# Altium schematic sheet Support.SchDoc
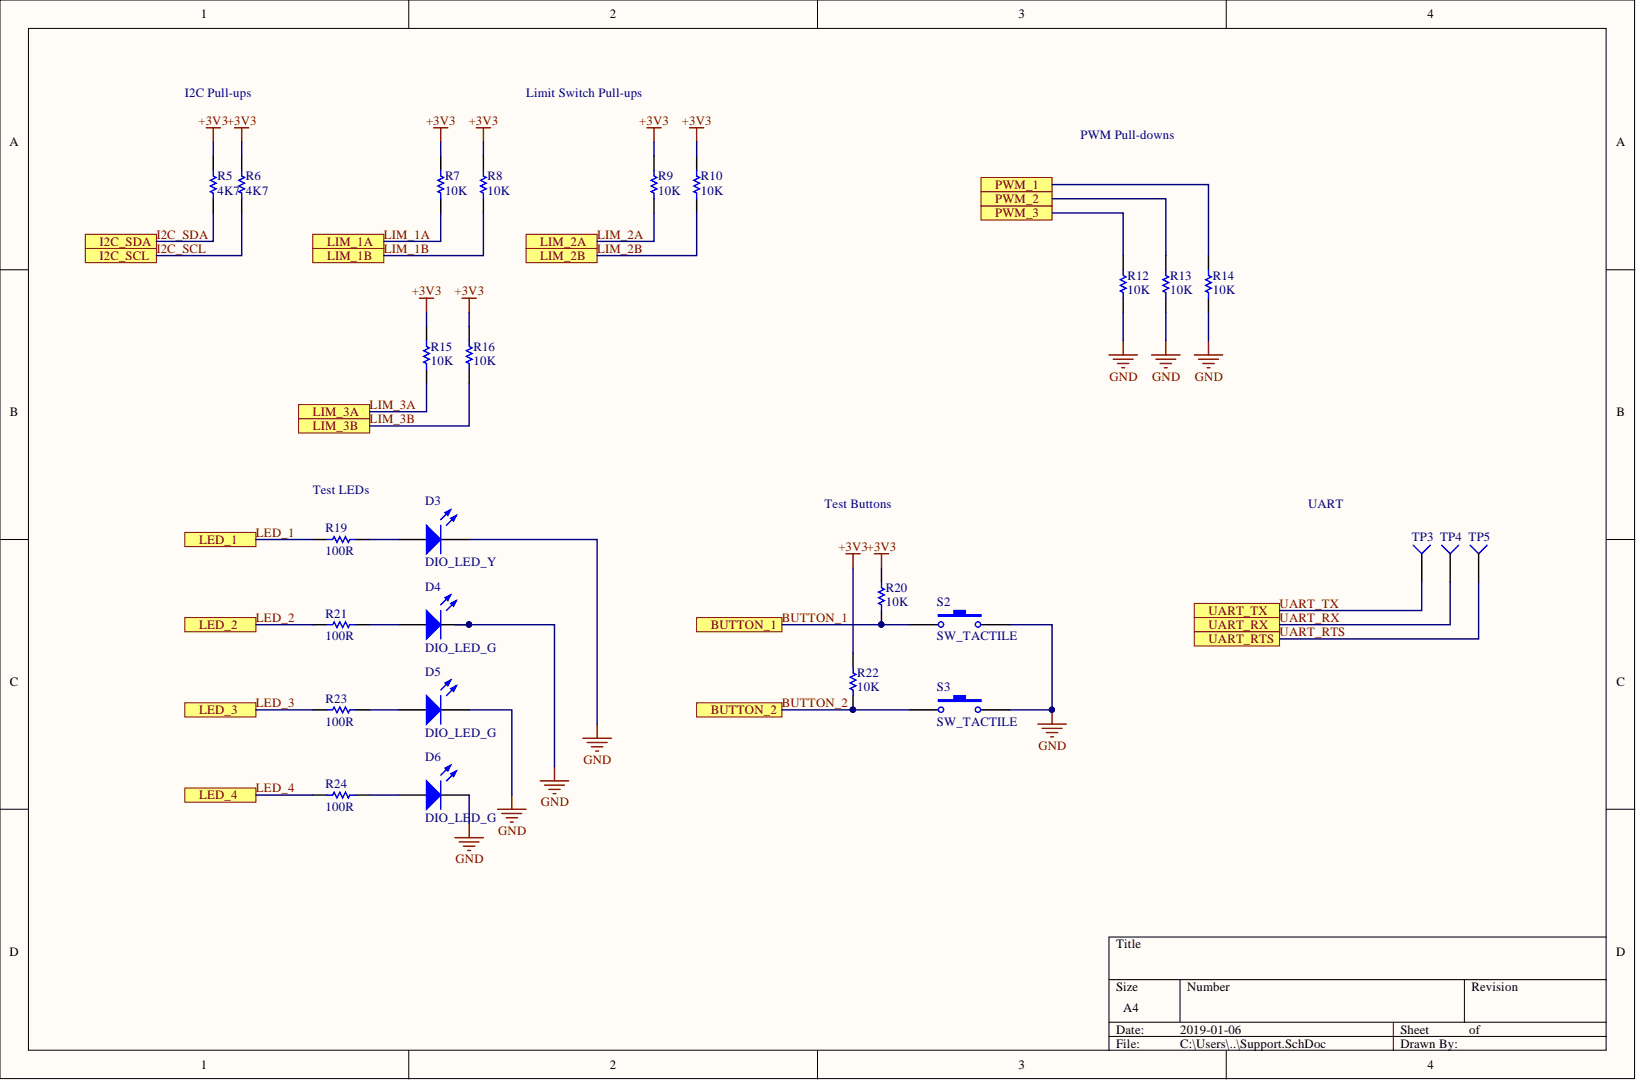
[diagram p0: Support.SchDoc]

## Parts
D3  DIO_LED_Y  [LED_0805]
D4  DIO_LED_G  [LED_0805]
D5  DIO_LED_G  [LED_0805]
D6  DIO_LED_G  [LED_0805]
R5  value 4K7  [RESC0603(1608)_N]  (hidden comment: RES_4K7_0603)
R6  value 4K7  [RESC0603(1608)_N]  (hidden comment: RES_4K7_0603)
R7  value 10K  [RESC0603(1608)_N]  (hidden comment: RES_10K_0603)
R8  value 10K  [RESC0603(1608)_N]  (hidden comment: RES_10K_0603)
R9  value 10K  [RESC0603(1608)_N]  (hidden comment: RES_10K_0603)
R10  value 10K  [RESC0603(1608)_N]  (hidden comment: RES_10K_0603)
R12  value 10K  [RESC0603(1608)_N]  (hidden comment: RES_10K_0603)
R13  value 10K  [RESC0603(1608)_N]  (hidden comment: RES_10K_0603)
R14  value 10K  [RESC0603(1608)_N]  (hidden comment: RES_10K_0603)
R15  value 10K  [RESC0603(1608)_N]  (hidden comment: RES_10K_0603)
R16  value 10K  [RESC0603(1608)_N]  (hidden comment: RES_10K_0603)
R19  value 100R  [RESC0603(1608)_N]  (hidden comment: RES_100R_0603)
R20  value 10K  [RESC0603(1608)_N]  (hidden comment: RES_10K_0603)
R21  value 100R  [RESC0603(1608)_N]  (hidden comment: RES_100R_0603)
R22  value 10K  [RESC0603(1608)_N]  (hidden comment: RES_10K_0603)
R23  value 100R  [RESC0603(1608)_N]  (hidden comment: RES_100R_0603)
R24  value 100R  [RESC0603(1608)_N]  (hidden comment: RES_100R_0603)
S2  SW_TACTILE  [B3U-1100P]
S3  SW_TACTILE  [B3U-1100P]
TP3  TESTPOINT  (value not drawn)
TP4  TESTPOINT  (value not drawn)
TP5  TESTPOINT  (value not drawn)

## Ports
BUTTON_1, BUTTON_2, I2C_SCL, I2C_SDA, LED_1, LED_2, LED_3, LED_4, LIM_1A, LIM_1B, LIM_2A, LIM_2B, LIM_3A, LIM_3B, PWM_1, PWM_2, PWM_3, UART_RTS, UART_RX, UART_TX

## Nets
+3V3: R5.2 R6.2 R7.2 R8.2 R9.2 R10.2 R15.2 R16.2 R20.2 R22.1
GND: D3.2 D4.2 D5.2 D6.2 R12.1 R13.1 R14.1 S2.2 S3.2
BUTTON_1: R20.1 S2.1 ; port BUTTON_1
BUTTON_2: R22.2 S3.1 ; port BUTTON_2
I2C_SCL: R6.1 ; port I2C_SCL
I2C_SDA: R5.1 ; port I2C_SDA
LED_1: R19.1 ; port LED_1
LED_2: R21.1 ; port LED_2
LED_3: R23.1 ; port LED_3
LED_4: R24.1 ; port LED_4
LIM_1A: R7.1 ; port LIM_1A
LIM_1B: R8.1 ; port LIM_1B
LIM_2A: R9.1 ; port LIM_2A
LIM_2B: R10.1 ; port LIM_2B
LIM_3A: R15.1 ; port LIM_3A
LIM_3B: R16.1 ; port LIM_3B
PWM_1: R14.2 ; port PWM_1
PWM_2: R13.2 ; port PWM_2
PWM_3: R12.2 ; port PWM_3
UART_RTS: TP5.1 ; port UART_RTS
UART_RX: TP4.1 ; port UART_RX
UART_TX: TP3.1 ; port UART_TX
NetD3_1: D3.1 R19.2
NetD4_1: D4.1 R21.2
NetD5_1: D5.1 R23.2
NetD6_1: D6.1 R24.2

## Library data of the parts
D3 = DIO_LED_Y: LED YELLOW DIFFUSED 0805 SMD; Manufacturer: OSRAM; Part Number: LY R976-PS-36; Forward Voltage Drop: 2.2V; Component Kind: Standard; Package: 0805 (2012 Metric); Simulation: LED1; Library Name: UWRT_Schematic_Library_2019.SchLib
D4-D6 = DIO_LED_G: LED GREEN DIFFUSED 0805 SMD; Manufacturer: OSRAM; Part Number: LG R971-KN-1; Forward Voltage Drop: 2.2V; Component Kind: Standard; Package: 0805 (2012 Metric); Simulation: LED1; Library Name: UWRT_Schematic_Library_2019.SchLib
R5 R6 = RES_4K7_0603: RES SMD 4.7K OHM 5% 1/10W 0603; Manufacturer: Yageo; Part Number (MPN): RC0603JR-074K7L; Component Kind: Standard; Dimensions: 0.063" L x 0.031" W (1.60mm x 0.80mm); Package: 0603 (1608 Metric); Signal Integrity: Res; Simulation: RESISTOR; Tolerance: 5%; Library Name: UWRT_Schematic_Library_2019.SchLib
R7-R10 R12-R16 R20 R22 = RES_10K_0603: RES SMD 10K OHM 5% 1/10W 0603; Manufacturer: Yageo; Part Number (MPN): RC0603JR-0710KL; Component Kind: Standard; Dimensions: 0.063" L x 0.031" W (1.60mm x 0.80mm); Package: 0603 (1608 Metric); Signal Integrity: Res; Simulation: RESISTOR; Tolerance: 5%; Library Name: UWRT_Schematic_Library_2019.SchLib
R19 R21 R23 R24 = RES_100R_0603: RES SMD 100 OHM 1% 1/10W 0603; Manufacturer: Yageo; Part Number (MPN): RC0603FR-07100RL; Component Kind: Standard; Dimensions: 0.063" L x 0.031" W (1.60mm x 0.80mm); Package: 0603 (1608 Metric); Signal Integrity: Res; Simulation: RESISTOR; Tolerance: 1%; Library Name: UWRT_Schematic_Library_2019.SchLib
S2 S3 = SW_TACTILE: Tactile Switch (Grey); Component Kind: Standard; Digikey Part Number: SW1021TR-ND; Manufacturer Part Number: B3U-1100P; Library Name: UWRT_Schematic_Library_2019.SchLib
TP3-TP5 = TESTPOINT: Component Kind: Standard; Library Name: UWRT_Schematic_Library_2019.SchLib
